# Altium schematic sheet P01.SchDoc(P01)
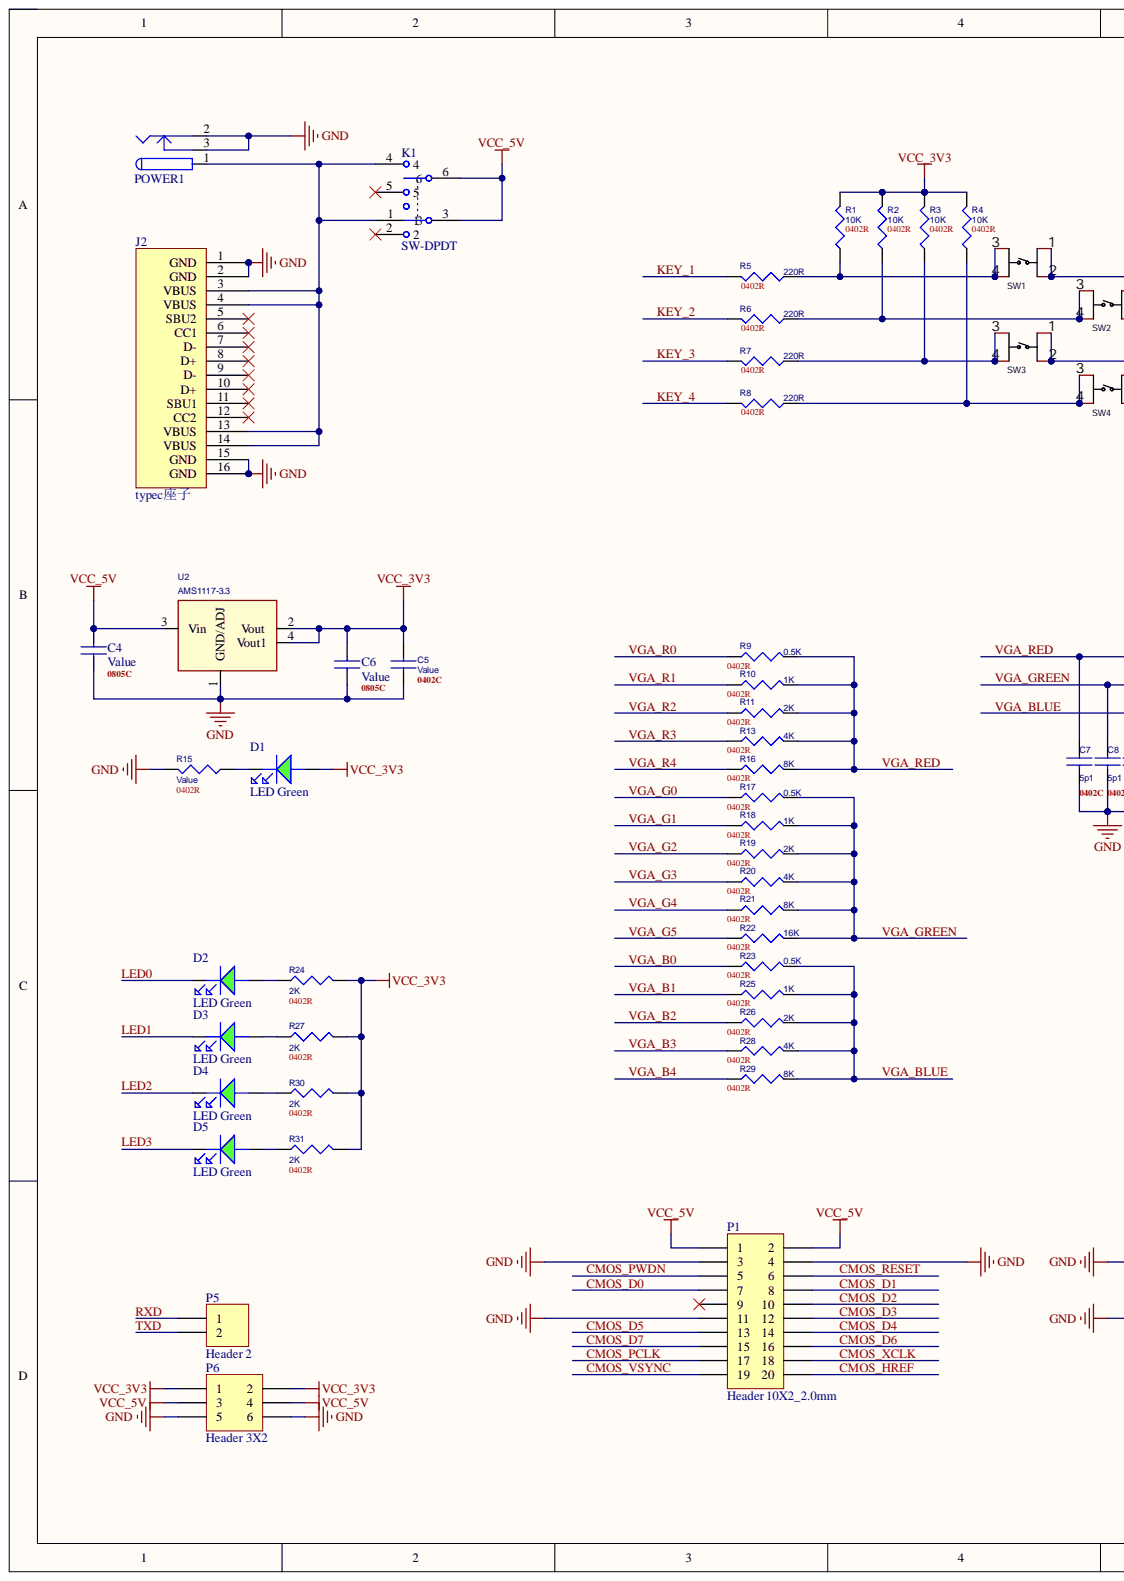
[diagram p0: P01.SchDoc, left]
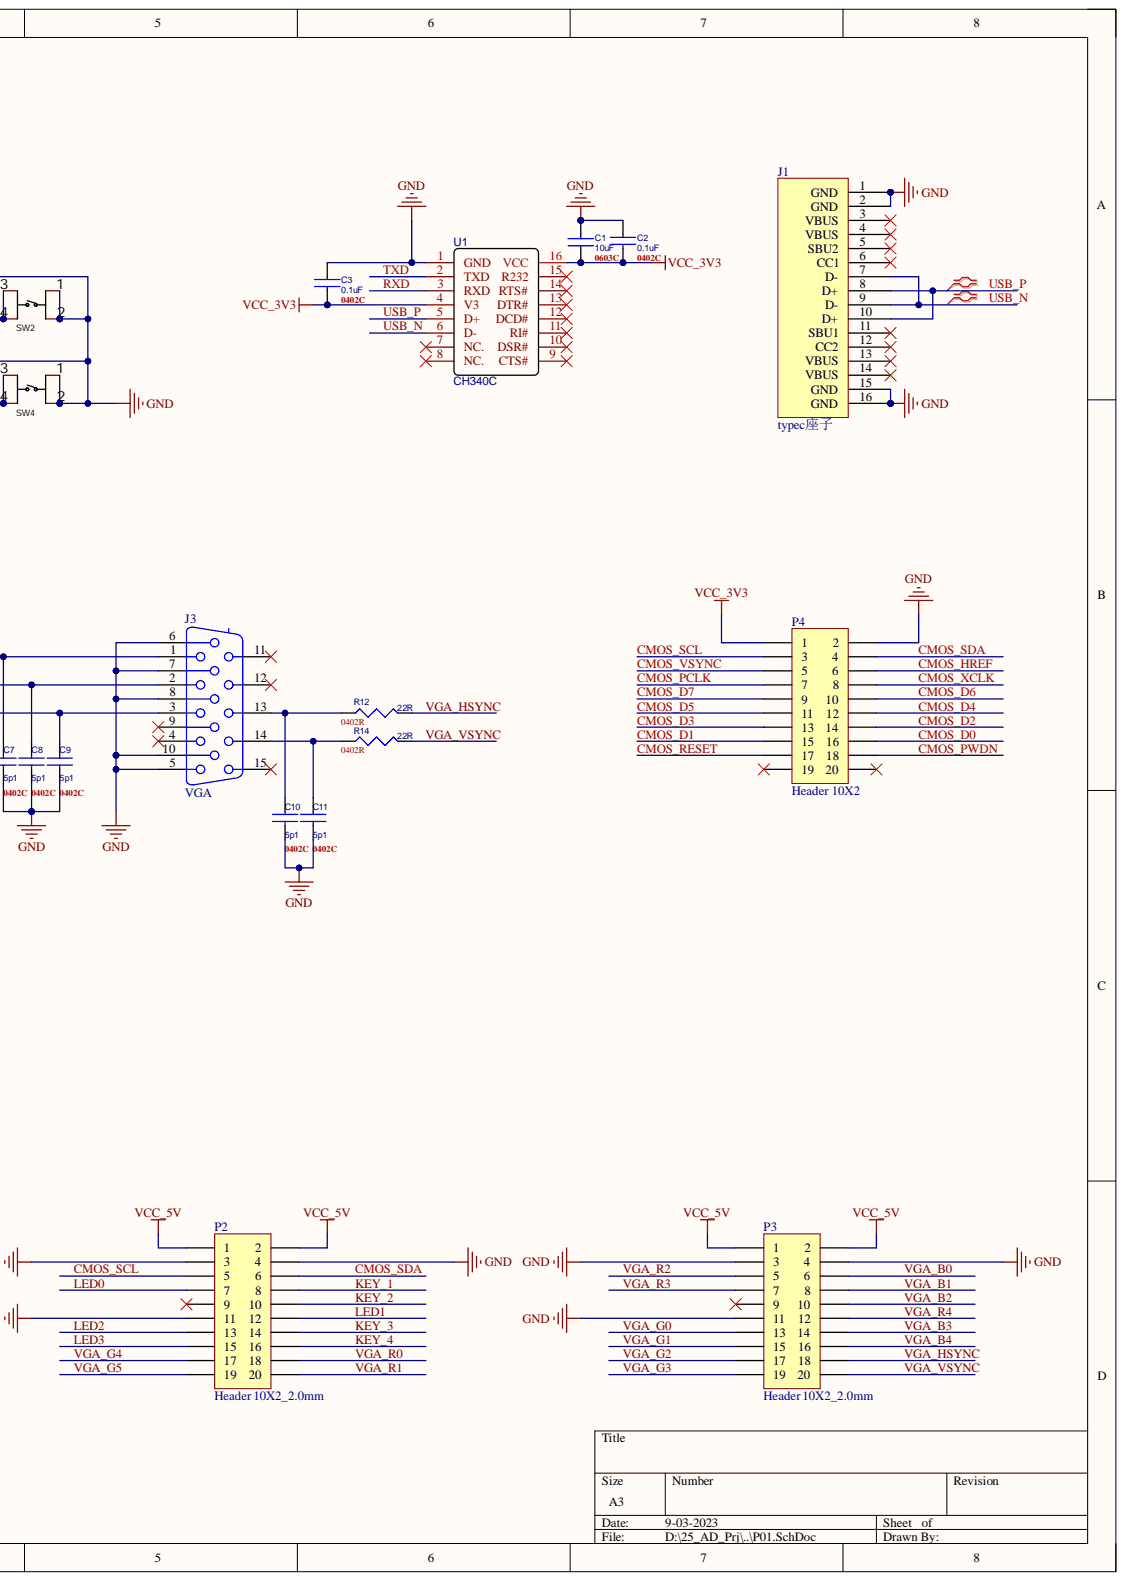
[diagram p1: P01.SchDoc, right]

## Parts
C1  10uF  Packag: 0603C  [C0603-Y]
C2  0.1uF  Packag: 0402C  [C0402-Y]
C3  0.1uF  Packag: 0402C  [C0402-Y]
C4  Value  Packag: 0805C  [C0805-Y]
C5  Value  Packag: 0402C  [C0402-Y]
C6  Value  Packag: 0805C  [C0805-Y]
C7  5p1  Packag: 0402C  [C0402-Y]
C8  5p1  Packag: 0402C  [C0402-Y]
C9  5p1  Packag: 0402C  [C0402-Y]
C10  5p1  Packag: 0402C  [C0402-Y]
C11  5p1  Packag: 0402C  [C0402-Y]
D1  LED Green  [0805_LED]
D2  LED Green  [0805_LED]
D3  LED Green  [0805_LED]
D4  LED Green  [0805_LED]
D5  LED Green  [0805_LED]
J1  typec座子  [USB-TYPEC_16PIN]
J2  typec座子  [USB-TYPEC_16PIN]
J3  VGA  [VGA]
K1  SW-DPDT  [SW6]
P1  Header 10X2_2.0mm  [HDR2X10_2.0mm]
P2  Header 10X2_2.0mm  [HDR2X10_2.0mm]
P3  Header 10X2_2.0mm  [HDR2X10_2.0mm]
P4  Header 10X2  [HDR2X10]
P5  Header 2  [HDR1X2]
P6  Header 3X2  [HDR2X3]
POWER1  PWR  [DC5.0]  (value not drawn)  (net tie)
R1  10K  Packag: 0402R  [R0402_S]
R2  10K  Packag: 0402R  [R0402_S]
R3  10K  Packag: 0402R  [R0402_S]
R4  10K  Packag: 0402R  [R0402_S]
R5  220R  Packag: 0402R  [R0402_S]
R6  220R  Packag: 0402R  [R0402_S]
R7  220R  Packag: 0402R  [R0402_S]
R8  220R  Packag: 0402R  [R0402_S]
R9  0.5K  Packag: 0402R  [R0402_S]
R10  1K  Packag: 0402R  [R0402_S]
R11  2K  Packag: 0402R  [R0402_S]
R12  22R  Packag: 0402R  [R0402_S]
R13  4K  Packag: 0402R  [R0402_S]
R14  22R  Packag: 0402R  [R0402_S]
R15  Value  Packag: 0402R  [R0402_S]
R16  8K  Packag: 0402R  [R0402_S]
R17  0.5K  Packag: 0402R  [R0402_S]
R18  1K  Packag: 0402R  [R0402_S]
R19  2K  Packag: 0402R  [R0402_S]
R20  4K  Packag: 0402R  [R0402_S]
R21  8K  Packag: 0402R  [R0402_S]
R22  16K  Packag: 0402R  [R0402_S]
R23  0.5K  Packag: 0402R  [R0402_S]
R24  2K  Packag: 0402R  [R0402_S]
R25  1K  Packag: 0402R  [R0402_S]
R26  2K  Packag: 0402R  [R0402_S]
R27  2K  Packag: 0402R  [R0402_S]
R28  4K  Packag: 0402R  [R0402_S]
R29  8K  Packag: 0402R  [R0402_S]
R30  2K  Packag: 0402R  [R0402_S]
R31  2K  Packag: 0402R  [R0402_S]
SW1  TS-KG89U-AT25F  [SW-SMD_4P-L4.2-W3.3-P2.15-LS4.7]  (value not drawn)
SW2  TS-KG89U-AT25F  [SW-SMD_4P-L4.2-W3.3-P2.15-LS4.7]  (value not drawn)
SW3  TS-KG89U-AT25F  [SW-SMD_4P-L4.2-W3.3-P2.15-LS4.7]  (value not drawn)
SW4  TS-KG89U-AT25F  [SW-SMD_4P-L4.2-W3.3-P2.15-LS4.7]  (value not drawn)
U1  CH340C  [SOP-16_L10.0-W3.9-P1.27-LS6.0-BL]
U2  AMS1117-3.3  [SOT-223]

## Nets
GND: C1.2 C2.1 C3.1 C4.1 C5.1 C6.1 C7.2 C8.2 C9.2 C10.2 C11.2 J1.1(GND) J1.2(GND) J1.15(GND) J1.16(GND) J2.1(GND) J2.2(GND) J2.15(GND) J2.16(GND) J3.5 J3.6 J3.7 J3.8 J3.10 P1.3 P1.4 P1.11 P2.3 P2.4 P2.11 P3.3 P3.4 P3.11 P4.2 P6.5 P6.6 POWER1.2 POWER1.3 R15.2 SW1.1 SW1.2 SW2.1 SW2.2 SW3.1 SW3.2 SW4.1 SW4.2 U1.1(GND) U2.1(GND/ADJ)
VCC_3V3: C1.1 C2.2 C3.2 C5.2 C6.2 D1.1 P4.1 P6.1 P6.2 R1.2 R2.2 R3.2 R4.2 R24.2 R27.2 R30.2 R31.2 U1.4(V3) U1.16(VCC) U2.2(Vout) U2.4(Vout1)
VCC_5V: C4.2 K1.3 K1.6 P1.1 P1.2 P2.1 P2.2 P3.1 P3.2 P6.3 P6.4 U2.3(Vin)
CMOS_D0: P1.7 P4.16
CMOS_D1: P1.8 P4.15
CMOS_D2: P1.10 P4.14
CMOS_D3: P1.12 P4.13
CMOS_D4: P1.14 P4.12
CMOS_D5: P1.13 P4.11
CMOS_D6: P1.16 P4.10
CMOS_D7: P1.15 P4.9
CMOS_HREF: P1.20 P4.6
CMOS_PCLK: P1.17 P4.7
CMOS_PWDN: P1.5 P4.18
CMOS_RESET: P1.6 P4.17
CMOS_SCL: P2.5 P4.3
CMOS_SDA: P2.6 P4.4
CMOS_VSYNC: P1.19 P4.5
CMOS_XCLK: P1.18 P4.8
KEY_1: P2.8 R5.2
KEY_2: P2.10 R6.2
KEY_3: P2.14 R7.2
KEY_4: P2.16 R8.2
LED0: D2.2 P2.7
LED1: D3.2 P2.12
LED2: D4.2 P2.13
LED3: D5.2 P2.15
RXD: P5.1 U1.3(RXD)
TXD: P5.2 U1.2(TXD)
USB_N: J1.7(D-) J1.9(D-) U1.6(D-)
USB_P: J1.8(D+) J1.10(D+) U1.5(D+)
VGA_B0: P3.6 R23.1
VGA_B1: P3.8 R25.1
VGA_B2: P3.10 R26.1
VGA_B3: P3.14 R28.1
VGA_B4: P3.16 R29.1
VGA_BLUE: C9.1 J3.3 R23.2 R25.2 R26.2 R28.2 R29.2
VGA_G0: P3.13 R17.1
VGA_G1: P3.15 R18.1
VGA_G2: P3.17 R19.1
VGA_G3: P3.19 R20.1
VGA_G4: P2.17 R21.1
VGA_G5: P2.19 R22.1
VGA_GREEN: C8.1 J3.2 R17.2 R18.2 R19.2 R20.2 R21.2 R22.2
VGA_HSYNC: P3.18 R12.2
VGA_R0: P2.18 R9.1
VGA_R1: P2.20 R10.1
VGA_R2: P3.5 R11.1
VGA_R3: P3.7 R13.1
VGA_R4: P3.12 R16.1
VGA_RED: C7.1 J3.1 R9.2 R10.2 R11.2 R13.2 R16.2
VGA_VSYNC: P3.20 R14.2
NetC10_1: C10.1 J3.13 R12.1
NetC11_1: C11.1 J3.14 R14.1
NetD1_2: D1.2 R15.1
NetD2_1: D2.1 R24.1
NetD3_1: D3.1 R27.1
NetD4_1: D4.1 R30.1
NetD5_1: D5.1 R31.1
NetJ2_3: J2.3(VBUS) J2.4(VBUS) J2.13(VBUS) J2.14(VBUS) K1.1 K1.4 POWER1.1
NetR1_1: R1.1 R5.1 SW1.3 SW1.4
NetR2_1: R2.1 R6.1 SW2.3 SW2.4
NetR3_1: R3.1 R7.1 SW3.3 SW3.4
NetR4_1: R4.1 R8.1 SW4.3 SW4.4

Not connected: J1 3(VBUS) 4(VBUS) 5(SBU2) 6(CC1) 11(SBU1) 12(CC2) 13(VBUS) 14(VBUS); J2 5(SBU2) 6(CC1) 7(D-) 8(D+) 9(D-) 10(D+) 11(SBU1) 12(CC2); J3 4 9 11 12 15; K1 2 5; P1 9; P2 9; P3 9; P4 19 20; U1 7(NC.) 8(NC.) 9(CTS#) 10(DSR#) 11(RI#) 12(DCD#) 13(DTR#) 14(RTS#) 15(R232)

## Library data of the parts
C1 = CAP 0603: Component Kind: Standard; Library Name: EBAZ4205_EXBOARD.SchLib
C2 C3 C5 C7-C11 = CAP 0402: Component Kind: Standard; Library Name: EBAZ4205_EXBOARD.SchLib
C4 C6 = CAP 0805: Component Kind: Standard; Library Name: EBAZ4205_EXBOARD.SchLib
D1-D5 = (no symbol name): LED Grün SML-P11MTT86 SMD; AN: 24211; Mixed: SMT; Preferred: Yes; Case: 1005 (0402); Component Kind: Standard; HelpURL: B:\AD\adlib\datasheet\SML-P1; Library Name: EBAZ4205_EXBOARD.SchLib
J1 J2 = typec座子: 仅usb2.0; Component Kind: Standard; Library Name: EBAZ4205_EXBOARD.SchLib
J3 = Connector 15: Receptacle Assembly, 15-Pin, Sim Line Connector; Gender: Receptacle Header; PackageDocument: B; Positions: 15; Component Kind: Standard; DatasheetDocument: 24-Nov-1999; LatestRevisionDate: 17-Jul-2002; LatestRevisionNote: Re-released for DXP Platform.; Library Name: vga.SchLib; Orientation: Right Angle; PackageReference: 788574; Published: 15-Jun-2001; Publisher: Altium Limited
K1 = SW-DPDT: Double-Pole, Double-Throw Switch; Component Kind: Standard; LatestRevisionDate: 17-Jul-2002; LatestRevisionNote: Re-released for DXP Platform.; PackageReference: DPDT-6; Published: 24-Mar-1999; Library Name: EBAZ4205_EXBOARD.SchLib; Publisher: Altium Limited
P1-P3 = Header 10X2_2.0mm: Header, 10-Pin, Dual row; Component Kind: Standard; LatestRevisionDate: 17-Jul-2002; LatestRevisionNote: Re-released for DXP Platform.; Signal Integrity: Connector; Library Name: EBAZ4205_EXBOARD.SchLib; Publisher: Altium Limited
P4 = Header 10X2: Header, 10-Pin, Dual row; Component Kind: Standard; LatestRevisionDate: 17-Jul-2002; LatestRevisionNote: Re-released for DXP Platform.; Library Name: Miscellaneous Connectors.IntLib; Signal Integrity: Connector; Publisher: Altium Limited
P5 = Header 2: Header, 2-Pin; Component Kind: Standard; LatestRevisionDate: 17-Jul-2002; LatestRevisionNote: Re-released for DXP Platform.; Library Name: Miscellaneous Connectors.IntLib; Signal Integrity: Connector; Publisher: Altium Limited
P6 = Header 3X2: Header, 3-Pin, Dual row; Component Kind: Standard; LatestRevisionDate: 17-Jul-2002; LatestRevisionNote: Re-released for DXP Platform.; Library Name: Miscellaneous Connectors.IntLib; Signal Integrity: Connector; Publisher: Altium Limited
POWER1 = PWR2.5: Low Voltage Power Supply Connector; ComponentLink1Description: Manufacturer Link; ComponentLink1URL: http://domino.kycon.com/internet/Products.html; DatasheetDocument: 09-May-2002; Published: 17-Dec-2003; Library Name: EBAZ4205_EXBOARD.SchLib; Publisher: Altium Limited
R1-R31 = R0402: Component Kind: Standard; Library Name: EBAZ4205_EXBOARD.SchLib
SW1-SW4 = TS-KG89U-AT25F: Manufacturer: HANBO(汉博); Actuator Style: 椭圆形按钮; Actuator/Cap Color: 白色; Add into BOM: yes; Circuit: 单刀单掷; Contact Current: 50mA; Insulation Resistance: 100MΩ; Mechanical Life: 10万次; Operating Force: 250gf@±50gf; Pin Style: J型引脚; Strike Gundam: 否; Switch Height: 2.5mm; Switch Length: 4.2mm; Switch Width: 3.25mm; Voltage Rating (DC): 12V; Component Kind: Standard; Convert to PCB: yes; Datasheet: https://atta.szlcsc.com/upload/public/pdf/source/20221110/1C48C11B31AFEED14544AB73D6F0416E.pdf; Device: TS-KG89U-AT25F; JLCPCB Part Class: Extended Part; LCSC Part Name: 4.2*3.25*2.5mm 立贴 轻触开关; Manufacturer Part: TS-KG89U-AT25F; Mounting Style: 立贴; Name: TS-KG89U-AT25F; PCB3D: SW-SMD_4P-L4.2-W3.3-P2.15-LS4.7; Supplier: LCSC; Supplier Footprint: SMD; Supplier Part: C2874600; Symbol: TS-KG89U-AT25F; Library Name: EBAZ4205_EXBOARD.SchLib
U1 = CH340C: BOM_Manufacturer: WCH; BOM_JLCPCB Part Class: Extended Part; BOM_Manufacturer Part: CH340C; BOM_Supplier: LCSC; BOM_Supplier Part: C84681; Component Kind: Standard; spicePre: U; spiceSymbolName: CH340C; Library Name: EBAZ4205_EXBOARD.SchLib
U2 = AMS1117: Component Kind: Standard; Library Name: EBAZ4205_EXBOARD.SchLib
